# Altium schematic sheet PMSM_500W_Controller_MCU.SchDoc
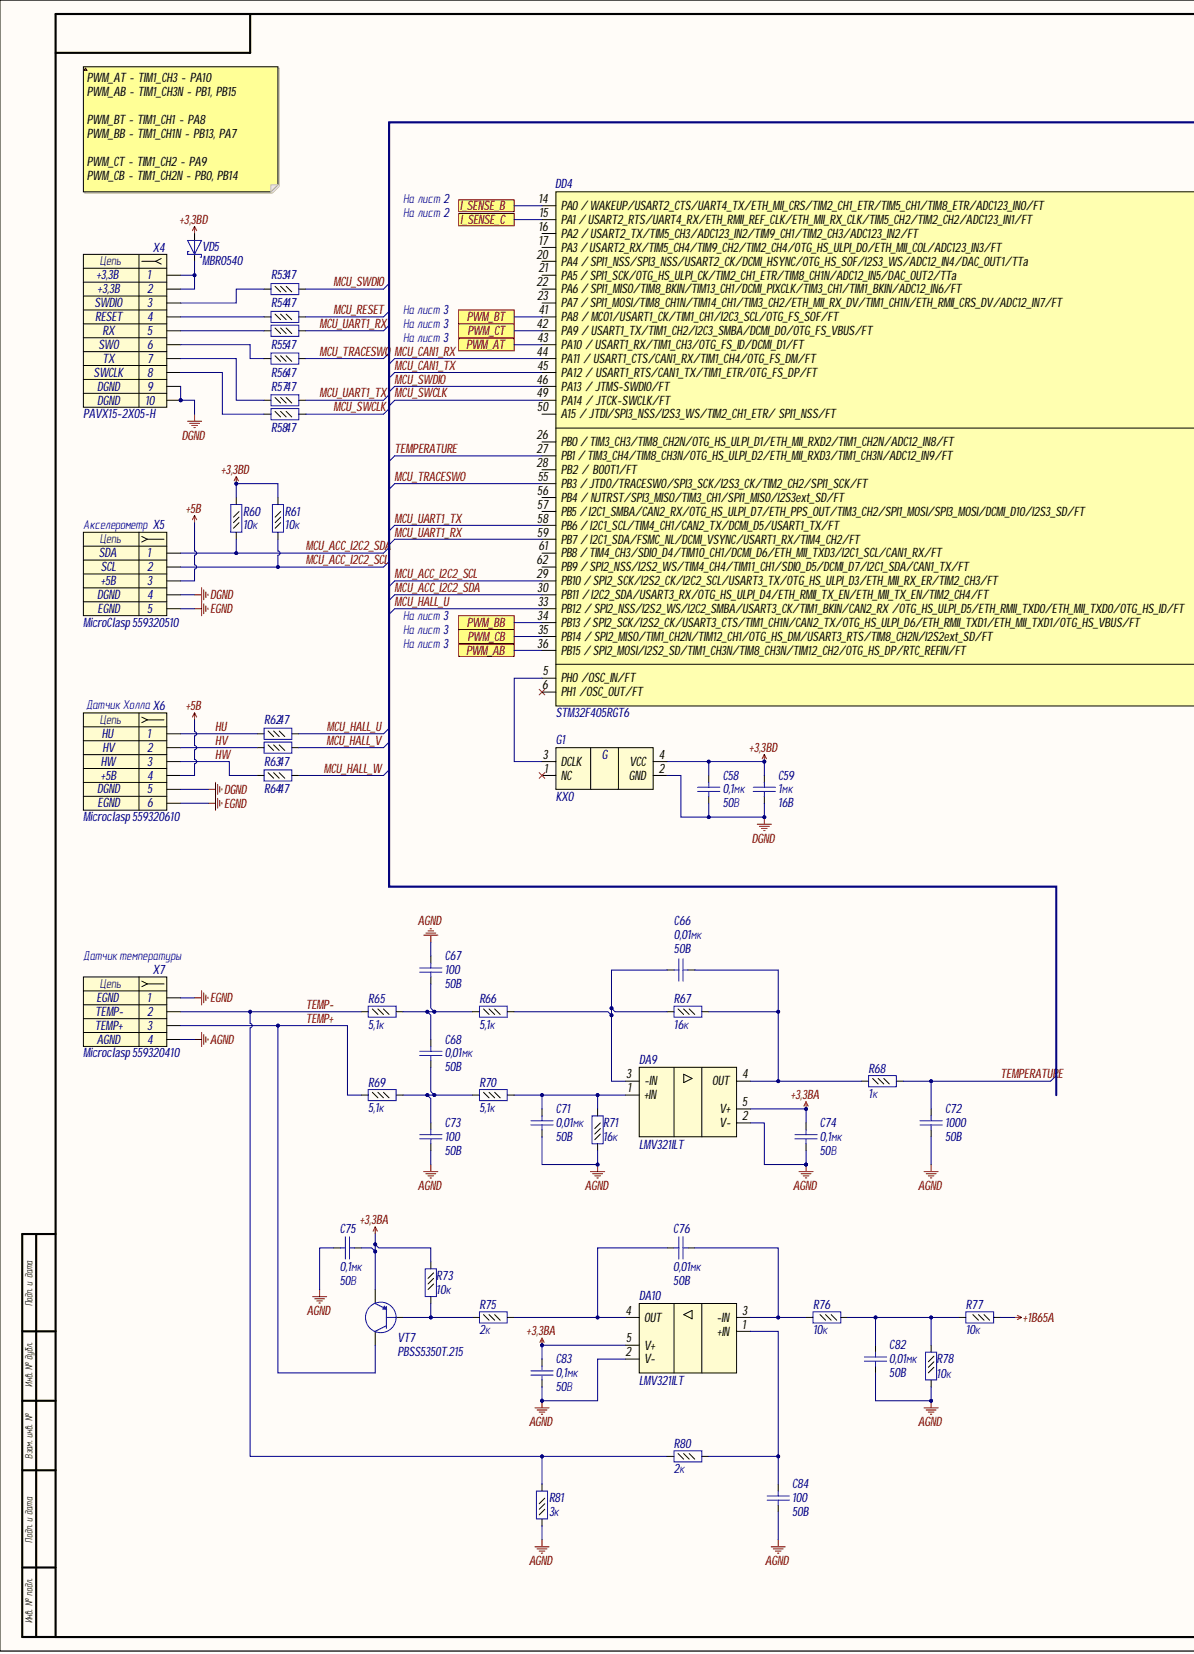
[diagram p0: PMSM_500W_Controller_MCU.SchDoc, left]
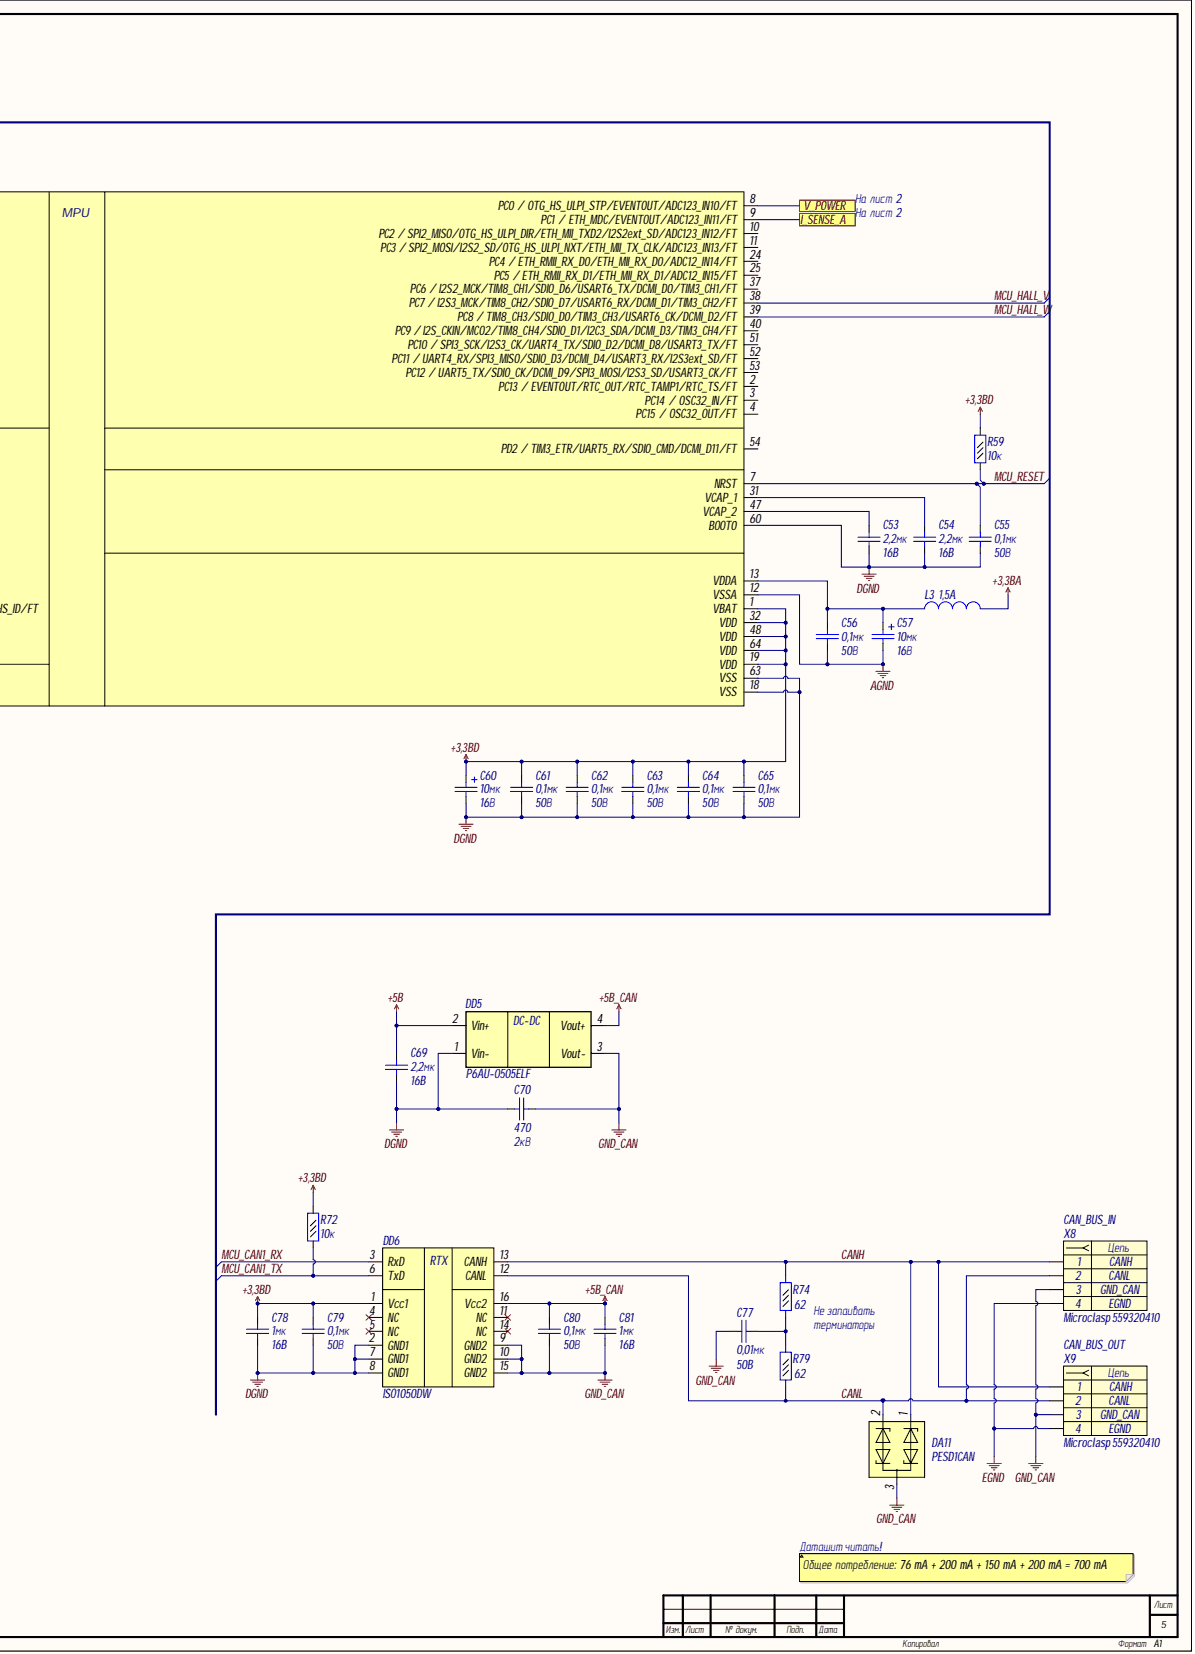
[diagram p1: PMSM_500W_Controller_MCU.SchDoc, right]

## Parts
C53  2,2мк  Voltage: 16B  [C0603]
C54  2,2мк  Voltage: 16B  [C0603]
C55  value 0,1мк  Voltage: 50В  [C0402]  (hidden comment: Capacitor)
C56  value 0,1мк  Voltage: 50В  [C0402]  (hidden comment: Capacitor)
C57  value 10мк  Voltage: 16В  [TANTAL CASE A]  (hidden comment: Capacitor_pol)
C58  value 0,1мк  Voltage: 50В  [C0402]  (hidden comment: Capacitor)
C59  value 1мк  Voltage: 16B  [C0603]  (hidden comment: Capacitor)
C60  value 10мк  Voltage: 16В  [TANTAL CASE A]  (hidden comment: Capacitor_pol)
C61  value 0,1мк  Voltage: 50В  [C0402]  (hidden comment: Capacitor)
C62  value 0,1мк  Voltage: 50В  [C0402]  (hidden comment: Capacitor)
C63  value 0,1мк  Voltage: 50В  [C0402]  (hidden comment: Capacitor)
C64  value 0,1мк  Voltage: 50В  [C0402]  (hidden comment: Capacitor)
C65  value 0,1мк  Voltage: 50В  [C0402]  (hidden comment: Capacitor)
C66  value 0,01мк  Voltage: 50B  [C0402]  (hidden comment: Capacitor)
C67  value 100  Voltage: 50B  [C0402]  (hidden comment: Capacitor)
C68  value 0,01мк  Voltage: 50B  [C0402]  (hidden comment: Capacitor)
C69  2,2мк  Voltage: 16B  [C0603]
C70  value 470  Voltage: 2кВ  [C1206]  (hidden comment: Capacitor)
C71  value 0,01мк  Voltage: 50B  [C0402]  (hidden comment: Capacitor)
C72  value 1000  Voltage: 50B  [C0402]  (hidden comment: Capacitor)
C73  value 100  Voltage: 50B  [C0402]  (hidden comment: Capacitor)
C74  value 0,1мк  Voltage: 50В  [C0402]  (hidden comment: Capacitor)
C75  value 0,1мк  [C0402]  (hidden comment: Capacitor)
C76  value 0,01мк  Voltage: 50B  [C0402]  (hidden comment: Capacitor)
C77  value 0,01мк  Voltage: 50B  [C0402]  (hidden comment: Capacitor)
C78  value 1мк  Voltage: 16B  [C0603]  (hidden comment: Capacitor)
C79  value 0,1мк  Voltage: 50В  [C0402]  (hidden comment: Capacitor)
C80  value 0,1мк  Voltage: 50В  [C0402]  (hidden comment: Capacitor)
C81  value 1мк  Voltage: 16B  [C0603]  (hidden comment: Capacitor)
C82  value 0,01мк  Voltage: 50B  [C0402]  (hidden comment: Capacitor)
C83  value 0,1мк  Voltage: 50В  [C0402]  (hidden comment: Capacitor)
C84  value 100  Voltage: 50B  [C0402]  (hidden comment: Capacitor)
DA9  LMV321ILT  [SOT23-5]
DA10  LMV321ILT  [SOT23-5]
DA11  PESD  [SOT23-3]
DD4  STM32F405RGT6  [LQFP64]
DD5  Part Number: P6AU-0505ELF  [SIP4]  (hidden comment: P6AU-xxxxELF)
DD6  Part Number: ISO1050DW  [SO16]
G1  KXO  [QSMD7x5.2x1.8]
L3  Rated Current: 1,5A  [L0603]  (hidden comment: Inductor)
R53  value 47  [R0402]  (hidden comment: Resistor_0,062Вт)
R54  value 47  [R0402]  (hidden comment: Resistor_0,062Вт)
R55  value 47  [R0402]  (hidden comment: Resistor_0,062Вт)
R56  value 47  [R0402]  (hidden comment: Resistor_0,062Вт)
R57  value 47  [R0402]  (hidden comment: Resistor_0,062Вт)
R58  value 47  [R0402]  (hidden comment: Resistor_0,062Вт)
R59  value 10к  [R0402]  (hidden comment: Resistor_0,062Вт)
R60  value 10к  [R0402]  (hidden comment: Resistor_0,062Вт)
R61  value 10к  [R0402]  (hidden comment: Resistor_0,062Вт)
R62  value 47  [R0402]  (hidden comment: Resistor_0,062Вт)
R63  value 47  [R0402]  (hidden comment: Resistor_0,062Вт)
R64  value 47  [R0402]  (hidden comment: Resistor_0,062Вт)
R65  value 5,1к  [R0402]  (hidden comment: Resistor_0,062Вт)
R66  value 5,1к  [R0402]  (hidden comment: Resistor_0,062Вт)
R67  value 16к  [R0402]  (hidden comment: Resistor_0,062Вт)
R68  value 1к  [R0402]  (hidden comment: Resistor_0,062Вт)
R69  value 5,1к  [R0402]  (hidden comment: Resistor_0,062Вт)
R70  value 5,1к  [R0402]  (hidden comment: Resistor_0,062Вт)
R71  value 16к  [R0402]  (hidden comment: Resistor_0,062Вт)
R72  value 10к  [R0402]  (hidden comment: Resistor_0,062Вт)
R73  value 10к  [R0402]  (hidden comment: Resistor_0,062Вт)
R74  value 62  [R0402]  (hidden comment: Resistor_0,062Вт)
R75  value 2к  [R0402]  (hidden comment: Resistor_0,062Вт)
R76  value 10к  [R0402]  (hidden comment: Resistor_0,062Вт)
R77  value 10к  [R0402]  (hidden comment: Resistor_0,062Вт)
R78  value 10к  [R0402]  (hidden comment: Resistor_0,062Вт)
R79  value 62  [R0402]  (hidden comment: Resistor_0,062Вт)
R80  value 2к  [R0402]  (hidden comment: Resistor_0,062Вт)
R81  value 3к  [R0402]  (hidden comment: Resistor_0,062Вт)
VD5  Part Number: MBR0540  [SOD-123]  (hidden comment: DiodeSchottky)
VT7  Part Number: PBSS5350T.215  Vceo max: 50В  [SOT23-3]  (hidden comment: BJT PNP)
X4  Contact_1: +3,3B  Contact_3: SWDIO  Contact_4: RESET  Contact_5: RX  Contact_6: SWO  Contact_7: TX  Contact_8: SWCLK  Contact_9: DGND  [PAVX15-2X05-H]  (hidden comment: Header_10_sct)
X5  Акселерометр  Contact_1: SDA  Contact_2: SCL  Contact_3: +5B  Contact_4: DGND  Contact_5: EGND  Part Name: MicroClasp  Part Number: 559320510  [MOLEX_559320510]
X6  Датчик Холла  Contact_1: HU  Contact_2: HV  Contact_3: HW  Contact_4: +5B  Contact_5: DGND  Contact_6: EGND  Part Number: 559320610  [MOLEX_559320610]
X7  Датчик температуры  Contact_1: EGND  Contact_2: TEMP-  Contact_3: TEMP+  Contact_4: AGND  Part Number: 559320410  [MOLEX_559320410]
X8  CAN_BUS_IN  Contact_1: CANH  Contact_2: CANL  Contact_3: GND_CAN  Contact_4: EGND  Part Number: 559320410  [MOLEX_559320410]
X9  CAN_BUS_OUT  Contact_1: CANH  Contact_2: CANL  Contact_3: GND_CAN  Contact_4: EGND  Part Number: 559320410  [MOLEX_559320410]

## Ports
I_SENSE_A, I_SENSE_B, I_SENSE_C, PWM_AB, PWM_AT, PWM_BB, PWM_BT, PWM_CB, PWM_CT, V_POWER

## Nets
+1B65A: R77.1
+3,3BA: C74.2 C75.1 C83.2 DA9.5(V+) DA10.5(V+) L3.2 R73.1 VT7.2
+3,3BD: C58.2 C59.2 C60.1 C61.2 C62.2 C63.2 C64.2 C65.2 C78.2 C79.2 DD4.1(VBAT) DD4.19(VDD) DD4.32(VDD) DD4.48(VDD) DD4.64(VDD) DD6.1(Vcc1) G1.4(VCC) R59.2 R60.2 R61.2 R72.2 VD5.2
+5B: C69.2 DD5.2(Vin+) X5.3 X6.4
+5B_CAN: C80.2 C81.2 DD5.4(Vout+) DD6.16(Vcc2)
AGND: C56.1 C57.2 C67.2 C71.1 C72.1 C73.1 C74.1 C75.2 C82.1 C83.1 C84.1 DA9.2(V-) DA10.2(V-) DD4.12(VSSA) R71.1 R78.2 R81.1 X7.4
DGND: C53.1 C54.1 C55.1 C58.1 C59.1 C60.2 C61.1 C62.1 C63.1 C64.1 C65.1 C69.1 C70.1 C78.1 C79.1 DD4.18(VSS) DD4.60(BOOT0) DD4.63(VSS) DD5.1(Vin-) DD6.2(GND1) DD6.7(GND1) DD6.8(GND1) G1.2(GND) X4.9 X4.10 X5.4 X6.5
EGND: X5.5 X6.6 X7.1 X8.4 X9.4
GND_CAN: C70.2 C77.1 C80.1 C81.1 DA11.3 DD5.3(Vout-) DD6.9(GND2) DD6.10(GND2) DD6.15(GND2) X8.3 X9.3
CANH: DA11.1 DD6.13(CANH) R74.2 X8.1 X9.1
CANL: DA11.2 DD6.12(CANL) R79.1 X8.2 X9.2
HU: R62.1 X6.1
HV: R63.1 X6.2
HW: R64.1 X6.3
I_SENSE_A: DD4.9(PC1_/_ETH_MDC/EVENTOUT/ADC123_IN11/FT) ; port I_SENSE_A
I_SENSE_B: DD4.14(PA0_/_WAKEUP/USART2_CTS/UART4_TX/ETH_MII_CRS/TIM2_CH1_ETR/TIM5_CH1/TIM8_ETR/ADC123_IN0/FT) ; port I_SENSE_B
I_SENSE_C: DD4.15(PA1_/_USART2_RTS/UART4_RX/ETH_RMII_REF_CLK/ETH_MII_RX_CLK/TIM5_CH2/TIM2_CH2/ADC123_IN1/FT) ; port I_SENSE_C
MCU_ACC_I2C2_SCL: DD4.29(PB10_/_SPI2_SCK/I2S2_CK/I2C2_SCL/USART3_TX/OTG_HS_ULPI_D3/ETH_MII_RX_ER/TIM2_CH3/FT) R61.1 X5.2
MCU_ACC_I2C2_SDA: DD4.30(PB11_/_I2C2_SDA/USART3_RX/OTG_HS_ULPI_D4/ETH_RMII_TX_EN/ETH_MII_TX_EN/TIM2_CH4/FT) R60.1 X5.1
MCU_CAN1_RX: DD4.44(PA11_/_USART1_CTS/CAN1_RX/TIM1_CH4/OTG_FS_DM/FT) DD6.3(RxD)
MCU_CAN1_TX: DD4.45(PA12_/_USART1_RTS/CAN1_TX/TIM1_ETR/OTG_FS_DP/FT) DD6.6(TxD) R72.1
MCU_HALL_U: DD4.33(PB12_/_SPI2_NSS/I2S2_WS/I2C2_SMBA/USART3_CK/TIM1_BKIN/CAN2_RX_/OTG_HS_ULPI_D5/ETH_RMII_TXD0/ETH_MII_TXD0/OTG_HS_ID/FT) R62.2
MCU_HALL_V: DD4.38(PC7_/_I2S3_MCK/TIM8_CH2/SDIO_D7/USART6_RX/DCMI_D1/TIM3_CH2/FT) R63.2
MCU_HALL_W: DD4.39(PC8_/_TIM8_CH3/SDIO_D0/TIM3_CH3/USART6_CK/DCMI_D2/FT) R64.2
MCU_RESET: C55.2 DD4.7(NRST) R54.2 R59.1
MCU_SWCLK: DD4.49(PA14_/_JTCK-SWCLK/FT) R58.2
MCU_SWDIO: DD4.46(PA13_/_JTMS-SWDIO/FT) R53.2
MCU_TRACESWO: DD4.55(PB3_/_JTDO/TRACESWO/SPI3_SCK/I2S3_CK/TIM2_CH2/SPI1_SCK/FT) R56.2
MCU_UART1_RX: DD4.59(PB7_/_I2C1_SDA/FSMC_NL/DCMI_VSYNC/USART1_RX/TIM4_CH2/FT) R55.2
MCU_UART1_TX: DD4.58(PB6_/_I2C1_SCL/TIM4_CH1/CAN2_TX/DCMI_D5/USART1_TX/FT) R57.2
PWM_AB: DD4.36(PB15_/_SPI2_MOSI/I2S2_SD/TIM1_CH3N/TIM8_CH3N/TIM12_CH2/OTG_HS_DP/RTC_REFIN/FT) ; port PWM_AB
PWM_AT: DD4.43(PA10_/_USART1_RX/TIM1_CH3/OTG_FS_ID/DCMI_D1/FT) ; port PWM_AT
PWM_BB: DD4.34(PB13_/_SPI2_SCK/I2S2_CK/USART3_CTS/TIM1_CH1N/CAN2_TX/OTG_HS_ULPI_D6/ETH_RMII_TXD1/ETH_MII_TXD1/OTG_HS_VBUS/FT) ; port PWM_BB
PWM_BT: DD4.41(PA8_/_MCO1/USART1_CK/TIM1_CH1/I2C3_SCL/OTG_FS_SOF/FT) ; port PWM_BT
PWM_CB: DD4.35(PB14_/_SPI2_MISO/TIM1_CH2N/TIM12_CH1/OTG_HS_DM/USART3_RTS/TIM8_CH2N/I2S2ext_SD/FT) ; port PWM_CB
PWM_CT: DD4.42(PA9_/_USART1_TX/TIM1_CH2/I2C3_SMBA/DCMI_D0/OTG_FS_VBUS/FT) ; port PWM_CT
TEMP+: R69.1 VT7.3 X7.3
TEMP-: R65.1 R80.1 R81.2 X7.2
TEMPERATURE: C72.2 DD4.27(PB1_/_TIM3_CH4/TIM8_CH3N/OTG_HS_ULPI_D2/ETH_MII_RXD3/TIM1_CH3N/ADC12_IN9/FT) R68.2
V_POWER: DD4.8(PC0_/_OTG_HS_ULPI_STP/EVENTOUT/ADC123_IN10/FT) ; port V_POWER
NetC53_2: C53.2 DD4.47(VCAP_2)
NetC54_2: C54.2 DD4.31(VCAP_1)
NetC56_2: C56.2 C57.1 DD4.13(VDDA) L3.1
NetC66_1: C66.1 DA9.4(OUT) R67.2 R68.1
NetC66_2: C66.2 DA9.3(-IN) R66.2 R67.1
NetC67_1: C67.1 C68.2 R65.2 R66.1
NetC68_1: C68.1 C73.2 R69.2 R70.1
NetC71_2: C71.2 DA9.1(+IN) R70.2 R71.2
NetC76_1: C76.1 DA10.3(-IN) R76.2
NetC76_2: C76.2 DA10.4(OUT) R75.2
NetC77_2: C77.2 R74.1 R79.2
NetC82_2: C82.2 R76.1 R77.2 R78.1
NetC84_2: C84.2 DA10.1(+IN) R80.2
NetDD4_5: DD4.5(PH0_/OSC_IN/FT) G1.3(DCLK)
NetR53_1: R53.1 X4.3
NetR54_1: R54.1 X4.4
NetR55_1: R55.1 X4.5
NetR56_1: R56.1 X4.6
NetR57_1: R57.1 X4.7
NetR58_1: R58.1 X4.8
NetR73_2: R73.2 R75.1 VT7.1
NetVD5_1: VD5.1 X4.1 X4.2

Not connected: DD4 2(PC13_/_EVENTOUT/RTC_OUT/RTC_TAMP1/RTC_TS/FT) 3(PC14_/_OSC32_IN/FT) 4(PC15_/_OSC32_OUT/FT) 6(PH1_/OSC_OUT/FT) 10(PC2_/_SPI2_MISO/OTG_HS_ULPI_DIR/ETH_MII_TXD2/I2S2ext_SD/ADC123_IN12/FT) 11(PC3_/_SPI2_MOSI/I2S2_SD/OTG_HS_ULPI_NXT/ETH_MII_TX_CLK/ADC123_IN13/FT) 16(PA2_/_USART2_TX/TIM5_CH3/ADC123_IN2/TIM9_CH1/TIM2_CH3/ADC123_IN2/FT) 17(PA3_/_USART2_RX/TIM5_CH4/TIM9_CH2/TIM2_CH4/OTG_HS_ULPI_D0/ETH_MII_COL/ADC123_IN3/FT) 20(PA4_/_SPI1_NSS/SPI3_NSS/USART2_CK/DCMI_HSYNC/OTG_HS_SOF/I2S3_WS/ADC12_IN4/DAC_OUT1/TTa) 21(PA5_/_SPI1_SCK/OTG_HS_ULPI_CK/TIM2_CH1_ETR/TIM8_CH1N/ADC12_IN5/DAC_OUT2/TTa) 22(PA6_/_SPI1_MISO/TIM8_BKIN/TIM13_CH1/DCMI_PIXCLK/TIM3_CH1/TIM1_BKIN/ADC12_IN6/FT) 23(PA7_/_SPI1_MOSI/TIM8_CH1N/TIM14_CH1/TIM3_CH2/ETH_MII_RX_DV/TIM1_CH1N/ETH_RMII_CRS_DV/ADC12_IN7/FT) 24(PC4_/_ETH_RMII_RX_D0/ETH_MII_RX_D0/ADC12_IN14/FT) 25(PC5_/_ETH_RMII_RX_D1/ETH_MII_RX_D1/ADC12_IN15/FT) 26(PB0_/_TIM3_CH3/TIM8_CH2N/OTG_HS_ULPI_D1/ETH_MII_RXD2/TIM1_CH2N/ADC12_IN8/FT) 28(PB2_/_BOOT1/FT) 37(PC6_/_I2S2_MCK/TIM8_CH1/SDIO_D6/USART6_TX/DCMI_D0/TIM3_CH1/FT) 40(PC9_/_I2S_CKIN/MCO2/TIM8_CH4/SDIO_D1/I2C3_SDA/DCMI_D3/TIM3_CH4/FT) 50(A15_/_JTDI/SPI3_NSS/I2S3_WS/TIM2_CH1_ETR/_SPI1_NSS/FT) 51(PC10_/_SPI3_SCK/I2S3_CK/UART4_TX/SDIO_D2/DCMI_D8/USART3_TX/FT) 52(PC11_/_UART4_RX/SPI3_MISO/SDIO_D3/DCMI_D4/USART3_RX/I2S3ext_SD/FT) 53(PC12_/_UART5_TX/SDIO_CK/DCMI_D9/SPI3_MOSI/I2S3_SD/USART3_CK/FT) 54(PD2_/_TIM3_ETR/UART5_RX/SDIO_CMD/DCMI_D11/FT) 56(PB4_/_NJTRST/SPI3_MISO/TIM3_CH1/SPI1_MISO/I2S3ext_SD/FT) 57(PB5_/_I2C1_SMBA/CAN2_RX/OTG_HS_ULPI_D7/ETH_PPS_OUT/TIM3_CH2/SPI1_MOSI/SPI3_MOSI/DCMI_D10/I2S3_SD/FT) 61(PB8_/_TIM4_CH3/SDIO_D4/TIM10_CH1/DCMI_D6/ETH_MII_TXD3/I2C1_SCL/CAN1_RX/FT) 62(PB9_/_SPI2_NSS/I2S2_WS/TIM4_CH4/TIM11_CH1/SDIO_D5/DCMI_D7/I2C1_SDA/CAN1_TX/FT); DD6 4(NC) 5(NC) 11(NC) 14(NC); G1 1(NC)

## Library data of the parts
C53 C54 C69 = cap287: Конденсатор; Manufacture: Murata; Part Name: Ceramic; Part Number: GRM188Z71C225ME43J; Database Table Name: Capacitors$; tga: 0,10%; TKE: X7R; Component Kind: Standard; id: cap287; Library Name: MOP44.DbLib; Value: 2,2мк
C55 C56 C58 C61-C65 C74 C79 C80 C83 = cap277: Конденсатор; Manufacture: Murata; Part Name: Ceramic; Part Number: GRM155R71H104KE14D; Database Table Name: Capacitors$; tga: 0,10%; TKE: X7R; Component Kind: Standard; id: cap277; Library Name: MOP44.DbLib
C57 C60 = cap199: Конденсатор полярный; Manufacture: Vishay; Part Name: Tantal; Part Number: 293D106X9016A2TE3; Database Table Name: Capacitors$; Component Kind: Standard; id: cap199; Library Name: MOP44.DbLib
C59 C78 C81 = cap133: Конденсатор; Manufacture: Murata; Part Name: Ceramic; Part Number: GRM188R71C105KA12D**; Database Table Name: Capacitors$; TKE: X7R; Component Kind: Standard; id: cap133; Library Name: MOP44.DbLib
C66 C68 C71 C76 C77 C82 = cap42: Конденсатор; Manufacture: Murata; Part Name: Ceramic; Part Number: GRM155R71H103K; Database Table Name: Capacitors$; TKE: X7R; Component Kind: Standard; id: cap42; Library Name: MOP44.DbLib
C67 C73 C84 = cap29: Конденсатор; Manufacture: Murata; Part Name: Ceramic; Part Number: GRM1535C1H101J; Database Table Name: Capacitors$; TKE: NP0; Component Kind: Standard; id: cap29; Library Name: MOP44.DbLib
C70 = cap284: Конденсатор; Manufacture: Yageo; Part Name: Ceramic; Part Number: CC1206KKX7RDBB471; Database Table Name: Capacitors$; TKE: X7R; Component Kind: Standard; id: cap284; Library Name: MOP44.DbLib
C72 = cap28: Конденсатор; Manufacture: Murata; Part Name: Ceramic; Part Number: GRM1555C1H102J; Database Table Name: Capacitors$; TKE: NP0; Component Kind: Standard; id: cap28; Library Name: MOP44.DbLib
C75 = cap277: Конденсатор; Manufacture: Murata; Part Name: Ceramic; Part Number: GRM155R71H104KE14D; Database Table Name: Capacitors$; tga: 0,10%; TKE: X7R; Voltage: 50В; Component Kind: Standard; id: cap277; Library Name: MOP44.DbLib
DA9 DA10 = amp10: HIGH-DRIVE OPERATIONAL AMPLIFIERS WITH SHUTDOWN; Manufacture: ST Microelectronics; Part Name: Micropower Operational Amplifiers; Bandwidth: 1MHz; Channels: 1; CMRR: 85dB; Database Table Name: Amplifier$; Input Bias Current: 10nA; Offset voltage: 0,1mB; PSRR: 80dB; Rail-to-rail: yes; Supply Current max: 200uA; Supply Voltage: 2,7-6B; Component Kind: Standard; id: amp10; Library Name: MOP44.DbLib; Part Number: LMV321ILT
DA11 = PESD1CAN: TVS; Manufacture: Nexperia; Part Name: CAN bus ESD protection diode; Breakdown Voltage: 25,4B; Capacitance: 17pF; Database Table Name: ESDdiode$; id: esd5; Max Reverse Voltage: 24B; Number of Channels: 2; Component Kind: Standard; Library Name: MOP44.DbLib; Part Number: PESD1CAN
DD4 = microcont10: Part Name: Microcontroller; ADC: 16x12b/2x12b; Database Table Name: Microcontroller$; Flash: 1Mb; Frequency: 168MHz; Interface: can, i2c, irda, lin, spi, uart, usbotg,sdio; Pin in: 51; Power Supply: 1.8-3.6V; Component Kind: Standard; id: microcont10; Library Name: MOP44.DbLib; Part Number: STM32F405RGT6
DD5 = pwr52: DC/DC преобразователь; Manufacturer: PEAK Electronics; Part Name: DC/DC Converter Isolated; Current: 200mA; Database Table Name: PowerTransducer$; Input Voltage: 5B; Component Kind: Standard; id: pwr52; Library Name: MOP44.DbLib; Output Voltage: 5B; Type: Isolated
DD6 = interf22: Isolated CAN Transceiver; Manufacture: Texas Instruments; Current Consumption: 76mA; Database Table Name: Interface$; Number of Channel: 1; Power Supply: 3-5,5В/4,75-5,25В; Type Supply: Single Polar; Component Kind: Standard; id: interf22; Library Name: MOP44.DbLib; Part Name: Isolated CAN Transceiver
G1 = crystal10: Кварц. генер. 32.768 МГц (HCMOS/TTL); Manufacture: GEYER; Part Number: KXO-V97; Database Table Name: Crystal$; Frequence: 12MHz; Component Kind: Standard; id: crystal10; Library Name: MOP44.DbLib
L3 = ind0: EMI Suppression Filter; Part Name: EMI Suppression Filters; Part Number: BLM18PG181SN1D; Database Table Name: Inductor$; Resistance: 0,09Ohm; Component Kind: Standard; id: ind0; Library Name: MOP44.DbLib
R53-R58 R62-R64 = res238: Резистор; Manufacture: Yageo; Part Number: RC0402FR-0747RL; Accuracy: 0,01; Database Table Name: Resistor$; Component Kind: Standard; id: res238; Library Name: MOP44.DbLib; Part Name: Resistor; Power: 0,062Вт
R59-R61 R72 R73 R76-R78 = res294: Резистор; Manufacture: Yageo; Part Number: RC0402FR-0710KL; Accuracy: 0,01; Database Table Name: Resistor$; Component Kind: Standard; id: res294; Library Name: MOP44.DbLib; Part Name: Resistor; Power: 0,062Вт
R65 R66 R69 R70 = res287: Резистор; Manufacture: Yageo; Part Number: RC0402FR-075K1; Accuracy: 0,01; Database Table Name: Resistor$; Component Kind: Standard; id: res287; Library Name: MOP44.DbLib; Part Name: Resistor; Power: 0,062Вт
R67 R71 = res299: Резистор; Manufacture: Yageo; Part Number: RC0402FR-0716KL; Accuracy: 0,01; Database Table Name: Resistor$; Component Kind: Standard; id: res299; Library Name: MOP44.DbLib; Part Name: Resistor; Power: 0,062Вт
R68 = res270: Резистор; Manufacture: Yageo; Part Number: RC0402FR-071KL; Accuracy: 0,01; Database Table Name: Resistor$; Component Kind: Standard; id: res270; Library Name: MOP44.DbLib; Part Name: Resistor; Power: 0,062Вт
R74 R79 = res241: Резистор; Manufacture: Yageo; Part Number: RC0402FR-0762RL; Accuracy: 0,01; Database Table Name: Resistor$; Component Kind: Standard; id: res241; Library Name: MOP44.DbLib; Part Name: Resistor; Power: 0,062Вт
R75 R80 = res277: Резистор; Manufacture: Yageo; Part Number: RC0402FR-072KL; Accuracy: 0,01; Database Table Name: Resistor$; Component Kind: Standard; id: res277; Library Name: MOP44.DbLib; Part Name: Resistor; Power: 0,062Вт
R81 = res281: Резистор; Manufacture: Yageo; Part Number: RC0402FR-073KL; Accuracy: 0,01; Database Table Name: Resistor$; Component Kind: Standard; id: res281; Library Name: MOP44.DbLib; Part Name: Resistor; Power: 0,062Вт
VD5 = diode15: Диод Шоттки; Manufacture: ON Semiconductor; Capacitance: 30pF; Database Table Name: Diode$; Forward Current: 0,5А; Forward Voltage: 0,62B; Max Reverse Voltage: 40B; Number of Channels: 1; Component Kind: Standard; id: diode15; Library Name: MOP44.DbLib; Part Name: Diode Schottky
VT7 = bjt6: Manufacture: NXP Semiconductor; Part Name: Transistor; Database Table Name: BJTTransistor$; Frequency: 100МГц; hfe: 300; Ic max: 2А; Power: 0,48Вт; Component Kind: Standard; id: bjt6; Library Name: MOP44.DbLib; Structure: pnp
X4 = connect107: Разъем - 10 конт. розетка; Manufacture: Auk Contractors; Part Name: Штыри двухрядные 2x5; Database Table Name: Connector$; Etch: 1.27mm; Component Kind: Standard; Contact_2: +3,3B; Contact_10: DGND; id: connect107; Library Name: MOP44.DbLib; Part Number: PAVX15-2X05-H
X5 = connect98: Разъем - 5 конт. розетка; Manufacture: Molex; Database Table Name: Connector$; Etch: 2mm; Component Kind: Standard; id: connect98; Library Name: MOP44.DbLib
X6 = connect99: Разъем - 6 конт. розетка; Manufacture: Molex; Part Name: MicroClasp; Database Table Name: Connector$; Etch: 2mm; Component Kind: Standard; id: connect99; Library Name: MOP44.DbLib
X7-X9 = connect97: Разъем - 4 конт. розетка; Manufacture: Molex; Part Name: MicroClasp Wire-to-Board Header; Database Table Name: Connector$; Etch: 2mm; Component Kind: Standard; id: connect97; Library Name: MOP44.DbLib
